# Altium schematic sheet HAL_4.SchDoc
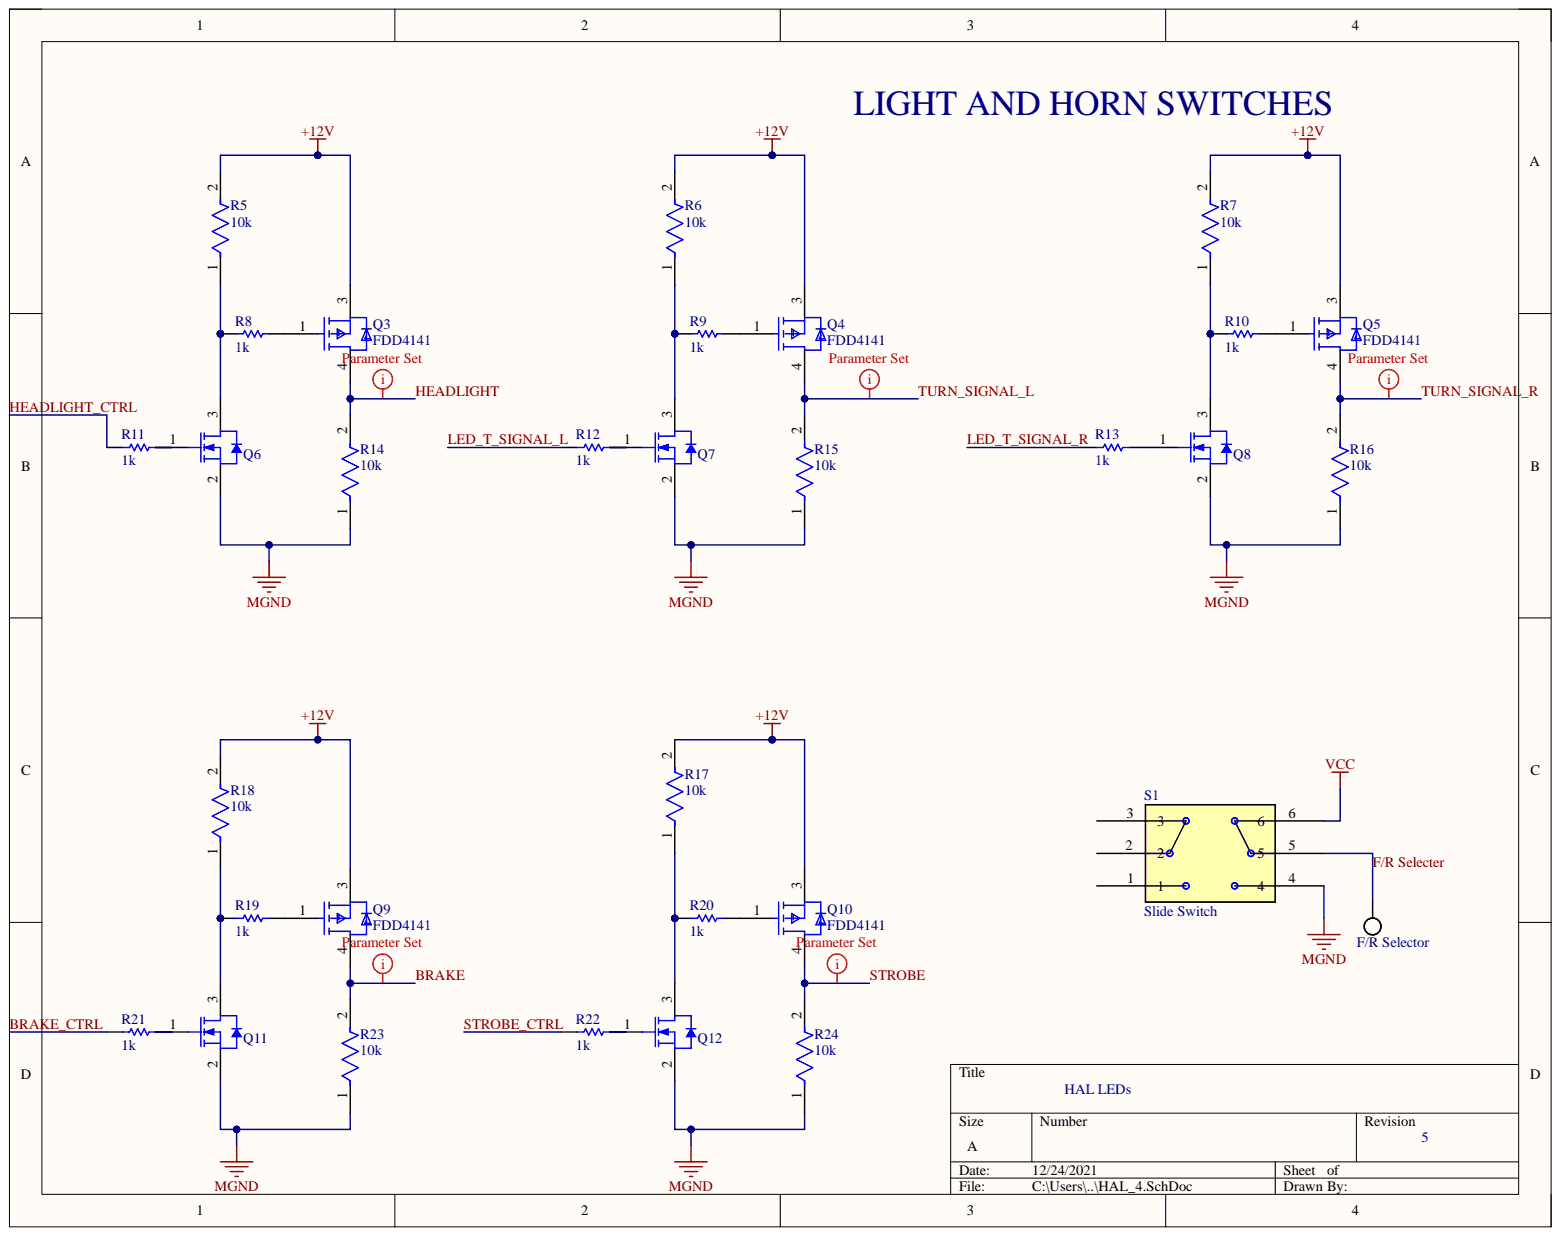
[diagram p0: HAL_4.SchDoc]

## Parts
F/R_Selector  F/R Selector  [EPAD]  (no BOM)
Q3  FDD4141  [FP-369AS-MFG]
Q4  FDD4141  [FP-369AS-MFG]
Q5  FDD4141  [FP-369AS-MFG]
Q6  NTR4501NT1G  [ONSC-SOT-23-3-318-08_V]  (value not drawn)
Q7  NTR4501NT1G  [ONSC-SOT-23-3-318-08_V]  (value not drawn)
Q8  NTR4501NT1G  [ONSC-SOT-23-3-318-08_V]  (value not drawn)
Q9  FDD4141  [FP-369AS-MFG]
Q10  FDD4141  [FP-369AS-MFG]
Q11  NTR4501NT1G  [ONSC-SOT-23-3-318-08_V]  (value not drawn)
Q12  NTR4501NT1G  [ONSC-SOT-23-3-318-08_V]  (value not drawn)
R5  10k  [FP-RMCF0805-MFG]
R6  10k  [FP-RMCF0805-MFG]
R7  10k  [FP-RMCF0805-MFG]
R8  1k  [RESC3216X70X40LL20T20]
R9  1k  [RESC3216X70X40LL20T20]
R10  1k  [RESC3216X70X40LL20T20]
R11  1k  [RESC3216X70X40LL20T20]
R12  1k  [RESC3216X70X40LL20T20]
R13  1k  [RESC3216X70X40LL20T20]
R14  10k  [FP-RMCF0805-MFG]
R15  10k  [FP-RMCF0805-MFG]
R16  10k  [FP-RMCF0805-MFG]
R17  10k  [FP-RMCF0805-MFG]
R18  10k  [FP-RMCF0805-MFG]
R19  1k  [RESC3216X70X40LL20T20]
R20  1k  [RESC3216X70X40LL20T20]
R21  1k  [RESC3216X70X40LL20T20]
R22  1k  [RESC3216X70X40LL20T20]
R23  10k  [FP-RMCF0805-MFG]
R24  10k  [FP-RMCF0805-MFG]
S1  Slide Switch  [DPDT Switch]

## Nets
+12V: Q3.3 Q4.3 Q5.3 Q9.3 Q10.3 R5.2 R6.2 R7.2 R17.2 R18.2
MGND: Q6.2 Q7.2 Q8.2 Q11.2 Q12.2 R14.1 R15.1 R16.1 R23.1 R24.1 S1.4
VCC: S1.6
BRAKE: Q9.4 R23.2
BRAKE_CTRL: R21.1
F/R Selecter: F/R_Selector.1 S1.5
HEADLIGHT: Q3.4 R14.2
HEADLIGHT_CTRL: R11.1
LED_T_SIGNAL_L: R12.1
LED_T_SIGNAL_R: R13.1
STROBE: Q10.4 R24.2
STROBE_CTRL: R22.1
TURN_SIGNAL_L: Q4.4 R15.2
TURN_SIGNAL_R: Q5.4 R16.2
NetQ3_1: Q3.1 R8.2
NetQ4_1: Q4.1 R9.2
NetQ5_1: Q5.1 R10.2
NetQ6_1: Q6.1 R11.2
NetQ6_3: Q6.3 R5.1 R8.1
NetQ7_1: Q7.1 R12.2
NetQ7_3: Q7.3 R6.1 R9.1
NetQ8_1: Q8.1 R13.2
NetQ8_3: Q8.3 R7.1 R10.1
NetQ9_1: Q9.1 R19.2
NetQ10_1: Q10.1 R20.2
NetQ11_1: Q11.1 R21.2
NetQ11_3: Q11.3 R18.1 R19.1
NetQ12_1: Q12.1 R22.2
NetQ12_3: Q12.3 R17.1 R20.1

Not connected: S1 1 2 3

## Library data of the parts
F/R_Selector = EPAD: Pad for probing signals; Library Name: PrISUm-Discrete.SchLib
Q3-Q5 Q9 Q10 = CMP-07173-000088-1: MOSFET P-CH 40V 10.8A DPAK; Manufacturer: ON Semiconductor; Continuous Drain Current: -10.8A; Depletion Mode: False; Drain To Source Breakdown Voltage: -40V; Drain To Source Resistance: 10.1mΩ; Gate Charge At Vgs: 36nC @ -10V; Gate To Source Voltage: 20V; Input Capacitace At Vds: 2085pF @ -20V; Max Forward Diode Voltage: -1.2V; Max Junction Temp: +150°C; Number Of Channels: 1; Power Dissipation: 2.4W; Pulse Drain Current: -100A; Reverse Recovery Charge: 26nC; Reverse Recovery Time: 29ns; Rthja Max: 52°C/W; Threshold Vgs Max: -3V; Threshold Vgs Min: -1V; Transconductance: 38S; Turn Off Delay Time: 38ns; Turn On Delay Time: 10ns; Typ Forward Diode Voltage: -0.8V; Automotive: No; Category: Diode; Component Kind: Standard; ComponentLink2Description: Datasheet; ComponentLink2URL: https://www.onsemi.com/pub/Collateral/FDD4141-D.pdf; Device Class L1: Discrete Semiconductors; Device Class L2: Transistors; Device Class L3: MOSFETs; Digikey Description: MOSFET P-CH 40V 10.8A DPAK; Drain To Source Voltage: -40V; Footprint Url: https://www.onsemi.com/pub/Collateral/369AS.PDF; Height: 2.517mm; Lead Free: Yes; Library Name: Altium Content Vault; Manufacturer Part Number: FDD4141; Mouser Description: MOSFET -40V P-Channel PowerTrench; Number Of P Channels: 1; Octopart: https://octopart.com/fdd4141-on+semiconductor-84325802; Package: 369AS; RoHS: TRUE; Temperature: -55°C to +150°C; Temperature Range High: +150°C; Temperature Range Low: -55°C
Q6-Q8 Q11 Q12 = CMP-1058-00732-1: Power MOSFET, 20 V, 3.2 A, Single N-Channel, 3-Pin SOT-23, Pb-Free, Tape and Reel; Manufacturer: On Semiconductor; Channel Polarity: N Channel; Ciss Typ (pF): 200; Coss Typ (pF): 80; Crss Typ (pF): 50; PD Max (W): 1.25; Qrr Typ (nC): 3; V(BR)DSS Min (V): 20; VGS Max (V): 12; VGS(th) Max (V): 1.2; Component Kind: Standard; ComponentLink1Description: Manufacturer URL; ComponentLink1URL: http://www.onsemi.com/; ComponentLink2Description: Datasheet; ComponentLink2URL: http://www.onsemi.cn/pub_link/Collateral/NTR4501N-D.PDF; ComponentLink3Description: Package Specification; ComponentLink3URL: http://www.onsemi.cn/pub_link/Collateral/318-08.PDF; Configuration: Single; DatasheetVersion: Rev. 13; Library Name: Altium Content Vault; Mounting Technology: Surface Mount; Package Type: SOT-23-3; PackageDescription: 3-Pin Small Outline Transistor, Body 2.92 x 1.3 mm, Pitch 0.95 mm; PackageReference: SOT-23-3-318-08; PackageVersion: Rev. AP, 11/2009; Packing: Tape and Reel; PartNumber: NTR4501NT1G; RoHS: Pb-Free
R5-R7 R14-R18 R23 R24 = CMP-26527-000121-1: RES 10K OHM 1% 1/8W 0805; Max Power Dissipation: 125mW; Resistance: 10kR; Temperature Coefficient: 100ppm/°C; Case Code (Imperial): 0805; Case Code (Metric): 2012; Case/Package: 0805; Component Kind: Standard; Construction: Rectangular; Contact Plating: Tin; Depth: 1.25mm; Features: Automotive AEC-Q200; Height: 0.026inch; Lead Free: Lead Free; Length: 2mm; Library Name: Altium Content Vault; Max Operating Temperature: 155°C; Military Standard: Not; Min Operating Temperature: -55°C; Mount: Surface Mount; Number of Terminations: 2; Packaging: Tape and Reel; Power Rating: 125mW; Radiation Hardening: No; Resistor Type: Thick Film; RoHS Compliant: Yes; Series: RMCF; Size Code: 0805; Tolerance: 1%; Width: 0.049inch
R8-R13 R19-R22 = CMP-2003-04517-1: RK73B2BTTD102J; Manufacturer: KOA Speer; Component Kind: Standard; Library Name: Altium Content Vault; Part Number: RK73B2BTTD102J
S1 = Slide Switch: Component Kind: Standard; Library Name: PrISUm-Discrete.SchLib
